# Altium schematic sheet gps.SchDoc
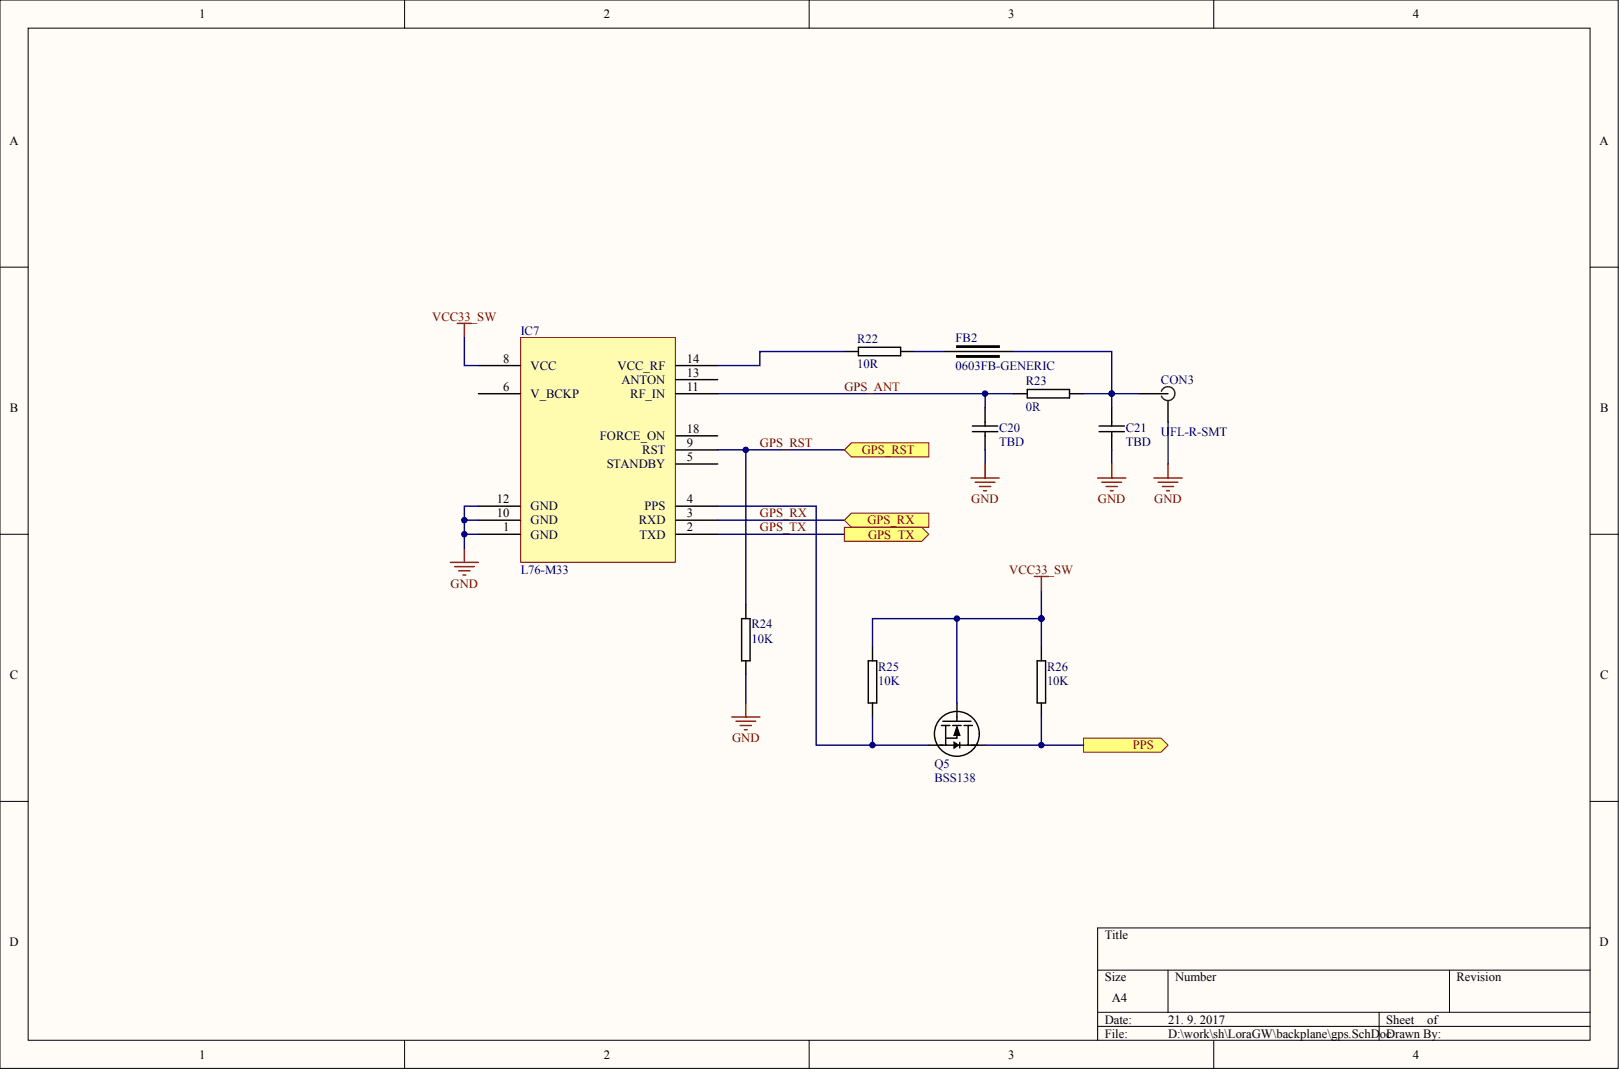
[diagram p0: gps.SchDoc]

## Parts
C20  TBD
C21  TBD
CON3  UFL-R-SMT
FB2  0603FB-GENERIC
IC7  L76-M33
Q5  BSS138
R22  10R
R23  0R
R24  10K
R25  10K
R26  10K

## Ports
GPS_RST, GPS_RX, GPS_TX, PPS

## Nets
GND: C20.2 C21.2 CON3.GND IC7.1(GND) IC7.10(GND) IC7.12(GND) R24.2
VCC33_SW: IC7.8(VCC) Q5.G R25.1 R26.1
GPS_ANT: C20.1 IC7.11(RF_IN) R23.1
GPS_RST: IC7.9(RST) R24.1 ; port GPS_RST
GPS_RX: IC7.3(RXD) ; port GPS_RX
GPS_TX: IC7.2(TXD) ; port GPS_TX
PPS: Q5.D R26.2 ; port PPS
NetC21_1: C21.1 CON3.SIG FB2.2 R23.2
NetFB2_1: FB2.1 R22.2
NetIC7_4: IC7.4(PPS) Q5.S R25.2
NetIC7_14: IC7.14(VCC_RF) R22.1

Not connected: IC7 5(STANDBY) 6(V_BCKP) 13(ANTON) 18(FORCE_ON)

## Library data of the parts
Q5 = (no symbol name): Manufacturer Part Number: BSS138 SMD; Manufacturer: ON Semiconductors; Drain to Source Voltage (Vdss): 50V; FET Feature: Logic Level Gate; Rds On (Max) @ Id, Vgs: 5.6 Ohm @ 200mA, 2.75V; Vgs(th) (Max) @ Id: 1.5V @ 1mA; Current - Continuous Drain (Id) @ 25° C: 200A; Input Capacitance (Ciss) @ Vds: 40pF @ 25V; Power - Max: 225mW; FET Type: MOSFET N-Channel, Metal Oxide; GME: 912-044; Category: Discrete Semiconductor Products; RoHS: RoHS Compliant; Mounting Type: Surface Mount; Package / Case: SOT-23-3, TO-236-3, Micro3™, SSD3, SST3; Packaging: Tape & Reel (TR)
R22 = (no symbol name): Manufacturer Part Number: RMCF 1/16 10 1% R; Manufacturer: Stackpole Electronics Inc; Reel: R48; Resistance In Ohms: 10.0; Tolerance: ±1%; Temperature Coefficient: ±100ppm/°C; Power (Watts): 0.1W, 1/10W; Supplier: Digi-Key; Supplier Part Number: RMCF1/1610FRDKR-ND; Composition: Thick Film; RoHS: RoHS Compliant; Category: Resistors; Lead Style: Surface Mount (SMD - SMT); Packaging: Digi-Reel®; Case: 0603 (1608 metric); Supplier 1: Digi-Key; Supplier Part Number 1: RMCF0603FT10R0TR-ND
R23 = (no symbol name): Manufacturer: Stackpole Electronics Inc; Manufacturer Part Number: RMC 1/16 0 G; Reel: R26; Supplier 1: Digi-Key; Supplier Part Number 1: RMCF0603ZT0R00TR-ND
R24-R26 = (no symbol name): Manufacturer: Stackpole Electronics Inc; Manufacturer Part Number: RMCF 1/16 10K 1% R; Tolerance: ±1%; Power (Watts): 0.1W, 1/10W; Temperature Coefficient: ±100ppm/°C; Resistance In Ohms: 10.0K; Reel: R21; Category: Resistors; Supplier Part Number: RMCF1/1610KFRDKR-ND; Lead Style: Surface Mount (SMD - SMT); RoHS: RoHS Compliant; Packaging: Digi-Reel®; Case: 0603 (1608 metric); Composition: Thick Film; Supplier: Digi-Key; Supplier 1: Digi-Key; Supplier Part Number 1: RMCF0603FT10K0DKR-ND
C20 C21 CON3 FB2 IC7 = (no symbol name)
  C20 C21: REEL: *
